# Altium schematic sheet mainboard_valdis.SchDoc
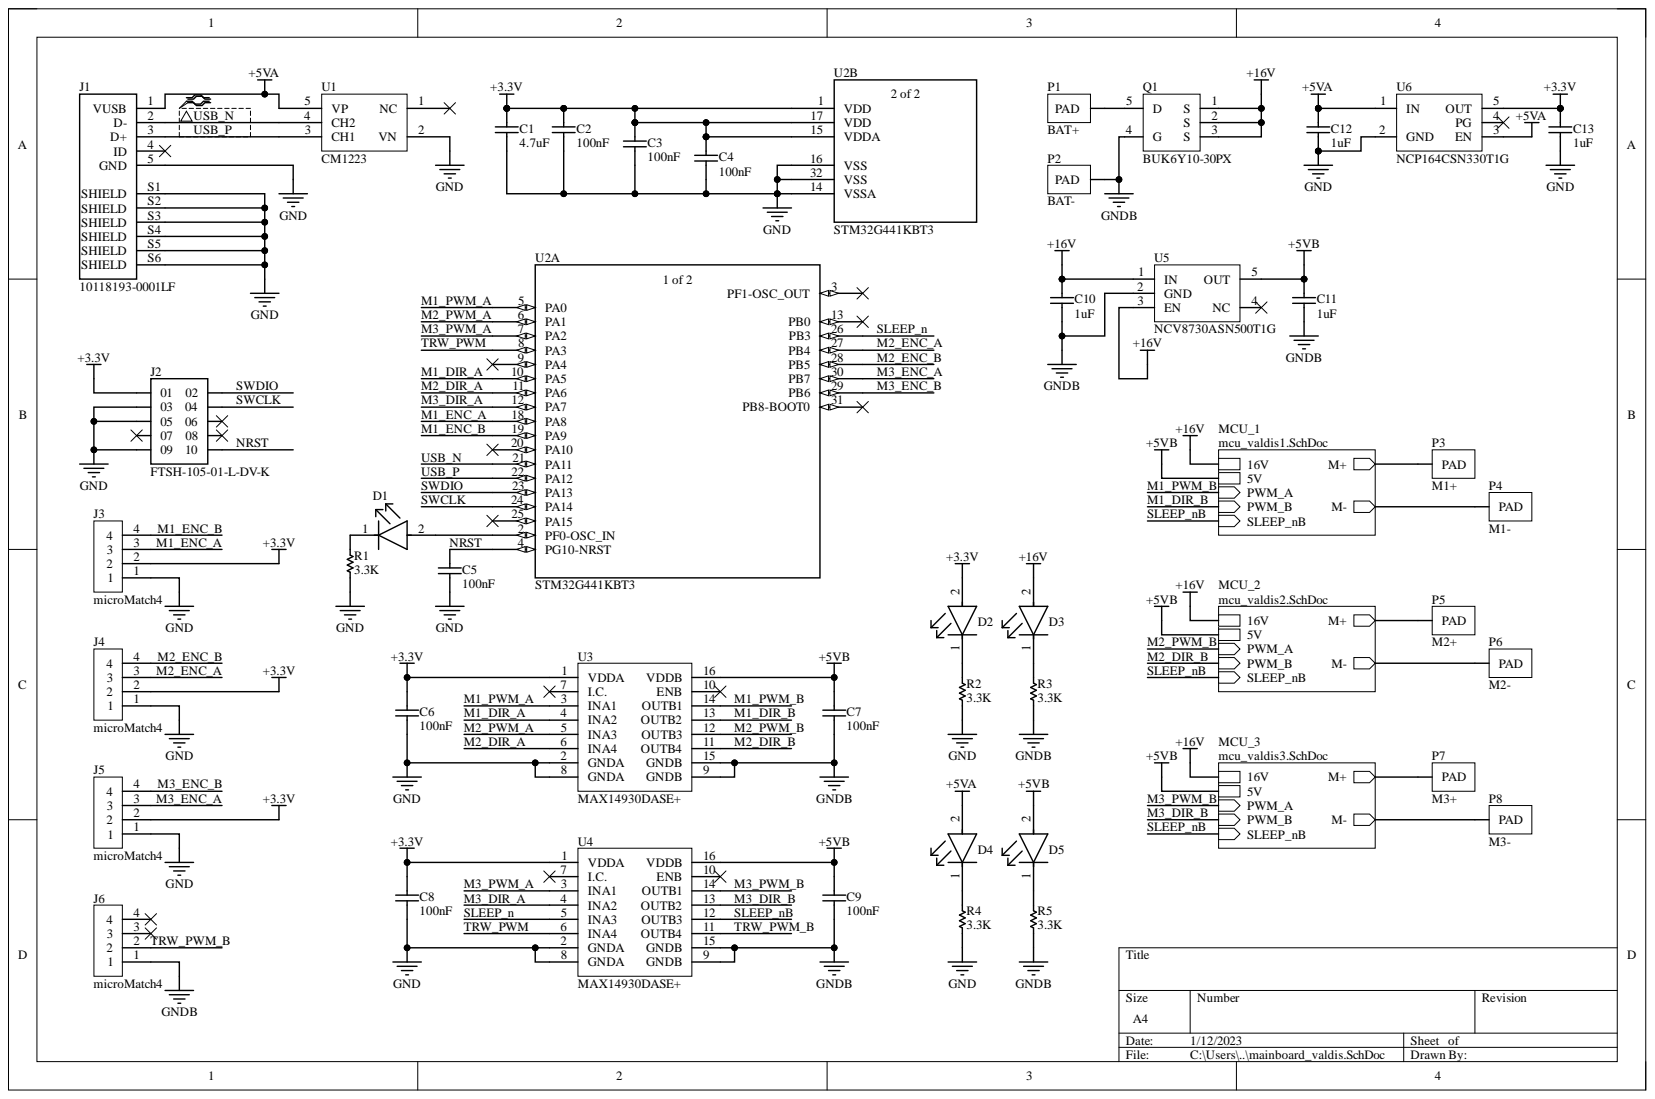
[diagram p0: mainboard_valdis.SchDoc]

## Parts
C1  4.7uF  [CAPC1608X90X35ML10T15]
C2  100nF  [CAPC1608X90X35ML10T15]
C3  100nF  [CAPC1608X90X35ML10T15]
C4  100nF  [CAPC1608X90X35ML10T15]
C5  100nF  [CAPC1608X90X35ML10T15]
C6  100nF  [CAPC1608X90X35ML10T15]
C7  100nF  [CAPC1608X90X35ML10T15]
C8  100nF  [CAPC1608X90X35ML10T15]
C9  100nF  [CAPC1608X90X35ML10T15]
C10  1uF  [CAPC1608X90X35ML10T15]
C11  1uF  [CAPC1608X90X35ML10T15]
C12  1uF  [CAPC1608X90X35ML10T15]
C13  1uF  [CAPC1608X90X35ML10T15]
D1  LTST-C191TBKT  [FP-LTST-C191TBKT-MFG]  (value not drawn)
D2  LTST-C191TBKT  [FP-LTST-C191TBKT-MFG]  (value not drawn)
D3  LTST-C191TBKT  [FP-LTST-C191TBKT-MFG]  (value not drawn)
D4  LTST-C191TBKT  [FP-LTST-C191TBKT-MFG]  (value not drawn)
D5  LTST-C191TBKT  [FP-LTST-C191TBKT-MFG]  (value not drawn)
J1  10118193-0001LF  [FCI_10118193-0001LF]
J2  FTSH-105-01-L-DV-K  [FTSH-105-01-XXX-DV-K]
J3  microMatch4  [microMatch4]
J4  microMatch4  [microMatch4]
J5  microMatch4  [microMatch4]
J6  microMatch4  [microMatch4]
P1  BAT+  [PCBComponent_1]
P2  BAT-  [PCBComponent_1]
P3  M1+  [PCBComponent_1]
P4  M1-  [PCBComponent_1]
P5  M2+  [PCBComponent_1]
P6  M2-  [PCBComponent_1]
P7  M3+  [PCBComponent_1]
P8  M3-  [PCBComponent_1]
Q1  BUK6Y10-30PX  [TO127P600X120-5N]
R1  3.3K  [RESC1609X50X30NL8T20]
R2  3.3K  [RESC1609X50X30NL8T20]
R3  3.3K  [RESC1609X50X30NL8T20]
R4  3.3K  [RESC1609X50X30NL8T20]
R5  3.3K  [RESC1609X50X30NL8T20]
U1  CM1223  [SOT23-5]
U2  STM32G441KBT3  [LQFP32_7X7_STM]  (parts A,B)
U3  MAX14930DASE+  [21-0041_S16+1_MXM]
U4  MAX14930DASE+  [21-0041_S16+1_MXM]
U5  NCV8730ASN500T1G  [TSSOP5]
U6  NCP164CSN330T1G  [SOP95P275X110-5N]

## Nets
+3.3V: C1.2 C2.2 C3.2 C4.2 C6.2 C8.2 C13.2 D2.2 J2.1 J3.2 J4.2 J5.2 U2.1(VDD) U2.15(VDDA) U2.17(VDD) U3.1(VDDA) U4.1(VDDA) U6.5(OUT)
+5VA: C12.2 D4.2 J1.1(VUSB) U1.5(VP) U6.1(IN) U6.3(EN)
+5VB: C7.2 C9.2 C11.2 D5.2 U3.16(VDDB) U4.16(VDDB) U5.5(OUT)
+16V: C10.2 D3.2 Q1.1(S) Q1.2(S) Q1.3(S) U5.1(IN) U5.3(EN)
GND: C1.1 C2.1 C3.1 C4.1 C5.1 C6.1 C8.1 C12.1 C13.1 J1.5(GND) J1.S1(SHIELD) J1.S2(SHIELD) J1.S3(SHIELD) J1.S4(SHIELD) J1.S5(SHIELD) J1.S6(SHIELD) J2.3 J2.5 J2.9 J3.1 J4.1 J5.1 R1.1 R2.1 R4.1 U1.2(VN) U2.14(VSSA) U2.16(VSS) U2.32(VSS) U3.2(GNDA) U3.8(GNDA) U4.2(GNDA) U4.8(GNDA) U6.2(GND)
GNDB: C7.1 C9.1 C10.1 C11.1 J6.1 P2.1 Q1.4(G) R3.1 R5.1 U3.9(GNDB) U3.15(GNDB) U4.9(GNDB) U4.15(GNDB) U5.2(GND)
M1_DIR_A: U2.10(PA5) U3.4(INA2)
M1_DIR_B: U3.13(OUTB2)
M1_ENC_A: J3.3 U2.18(PA8)
M1_ENC_B: J3.4 U2.19(PA9)
M1_PWM_A: U2.5(PA0) U3.3(INA1)
M1_PWM_B: U3.14(OUTB1)
M2_DIR_A: U2.11(PA6) U3.6(INA4)
M2_DIR_B: U3.11(OUTB4)
M2_ENC_A: J4.3 U2.27(PB4)
M2_ENC_B: J4.4 U2.28(PB5)
M2_PWM_A: U2.6(PA1) U3.5(INA3)
M2_PWM_B: U3.12(OUTB3)
M3_DIR_A: U2.12(PA7) U4.4(INA2)
M3_DIR_B: U4.13(OUTB2)
M3_ENC_A: J5.3 U2.30(PB7)
M3_ENC_B: J5.4 U2.29(PB6)
M3_PWM_A: U2.7(PA2) U4.3(INA1)
M3_PWM_B: U4.14(OUTB1)
NRST: C5.2 J2.10 U2.4(PG10-NRST)
SLEEP_n: U2.26(PB3) U4.5(INA3)
SLEEP_nB: U4.12(OUTB3)
SWCLK: J2.4 U2.24(PA14)
SWDIO: J2.2 U2.23(PA13)
TRW_PWM: U2.8(PA3) U4.6(INA4)
TRW_PWM_B: J6.2 U4.11(OUTB4)
USB_N: J1.2(D-) U1.4(CH2) U2.21(PA11)
USB_P: J1.3(D+) U1.3(CH1) U2.22(PA12)
NetD1_1: D1.1 R1.2
NetD1_2: D1.2 U2.2(PF0-OSC_IN)
NetD2_1: D2.1 R2.2
NetD3_1: D3.1 R3.2
NetD4_1: D4.1 R4.2
NetD5_1: D5.1 R5.2
NetJ1_4: J1.4(ID)
NetJ2_6: J2.6
NetJ2_7: J2.7
NetJ2_8: J2.8
NetJ6_3: J6.3
NetJ6_4: J6.4
NetP1_1: P1.1 Q1.5(D)
NetP3_1: P3.1
NetP4_1: P4.1
NetP5_1: P5.1
NetP6_1: P6.1
NetP7_1: P7.1
NetP8_1: P8.1
NetU1_1: U1.1(NC)
NetU2_3: U2.3(PF1-OSC_OUT)
NetU2_9: U2.9(PA4)
NetU2_13: U2.13(PB0)
NetU2_20: U2.20(PA10)
NetU2_25: U2.25(PA15)
NetU2_31: U2.31(PB8-BOOT0)
NetU3_7: U3.7(I.C.)
NetU3_10: U3.10(ENB)
NetU4_7: U4.7(I.C.)
NetU4_10: U4.10(ENB)
NetU5_4: U5.4(NC)
NetU6_4: U6.4(PG)

## Library data of the parts
C1-C13 = CMP-2000-04945-1: Chip Capacitor, 22 pF, +/- 5%, 50 V, -55 to 125 degC, 0603 (1608 Metric), RoHS, Tape and Reel; Manufacturer: Murata; Capacitance: 22pF; Dielectric: C0G; Rated Voltage: 50V; Case Size/Dimension: 0603; Component Kind: Standard; Datasheet URL: https://datasheet.ciiva.com/11786/0900766b807a1cab-11786398.pdf; Datasheet Version: Jul-06; Library Name: Altium Content Vault; Manufacturer URL: http://www.murata.com/; Package Description: 2-Pin Surface Mount Device, Body 1.6 x 0.8 mm; Package Reference: 603; Tolerance (%): ±5%
D1-D5 = CMP-22017-000008-1: LED BLUE CLEAR CHIP SMD; Dominant Wavelength: 475nm; Forward Current: 20mA; Forward Voltage: 3.8V; Lens Color: Colorless; Lens Style: Diffused; Luminous Intensity: 180mcd; Max Power Dissipation: 75mW; Number of Elements: 1; Power Dissipation: 76mW; Reverse Voltage: 5V; Viewing Angle: 130°; Wavelength: 468nm; Case Code (Imperial): 0603; Case Code (Metric): 1608; Case/Package: 0603; Color: Blue; Component Kind: Standard; Depth: 1.6mm; Height: 0.55mm; Illumination Color: Blue; Lead Free: Lead Free; Length: 0.8mm; Lens Transparency: Clear; Library Name: Altium Content Vault; Max Operating Temperature: 80°C; Min Operating Temperature: -20°C; Mount: Surface Mount; Number of LEDs: 1; Number of Pins: 2; Package Quantity: 1; Packaging: Tape and Reel; Radiation Hardening: No; Test Current: 20mA; Width: 0.8mm
J1 = 10118193-0001LF: USB - micro B USB 2.0 Receptacle Connector 5 Position Surface Mount, Right Angle; Through Hole; MF: Amphenol ICC; Availability: Unavailable; Component Kind: Standard; MP: 10118193-0001LF; Package: None; Price: None; SnapEDA_Link: https://www.snapeda.com/parts/10118193-0001LF/Amphenol%20FCI/view-part/2758989/?ref=snap; Library Name: Valdis_Components.IntLib
P1-P8 = Pad4x4: Component Kind: Standard; Library Name: Valdis_Components.IntLib
R1-R5 = CMP-2000-03816-5: Chip Resistor, 0 Ohm, Jumper, 100 mW, -55 to 155 degC, 0603 (1608 Metric), RoHS, Tape and Reel; Manufacturer: Vishay Semiconductor; Voltage Rating: 75 V; Component Kind: Standard; ComponentLink1Description: Manufacturer URL; ComponentLink1URL: http://www.vishay.com/; ComponentLink2Description: Datasheet; ComponentLink2URL: http://www.vishay.com/docs/20035/dcrcwe3.pdf; DatasheetVersion: 06/2012; Height: 0.45 mm; Length: 1.55 mm; Library Name: Altium Content Vault; Max Operating Temperature: 155 degC; Min Operating Temperature: -55 degC; Mount: Surface Mount; Mounting Technology: Surface Mount; Package Quantity: 5000; PackageDescription: 2-Pin Surface Mount Device, Body 1.575 x 0.85 mm; PackageReference: 0603; Packaging: Tape and Reel; Pins: 2; REACH SVHC: Unknown; RoHSCompliant: true
U2 = STM32G441KBT3: No Description Available; Built_By: EMA_Cory; SourceLibrary: Add_to_database_2020-11-05; Vendor: STMicroelectronics; Component Kind: Standard; Datasheet: https://www.st.com/resource/en/datasheet/stm32g441kb.pdf; Manufacturer_Part_Number: STM32G441KBT3; RefDes: RefDes; Type: DEV; Copyright: Copyright (C) 2018 Accelerated Designs. All rights reserved; Library Name: Valdis_Components.IntLib
J2 = FTSH-105-01-L-DV-K: Component Kind: Standard; Library Name: Valdis_Components.IntLib
J3-J6 = microMatch4: Component Kind: Standard; Library Name: Valdis_Components.IntLib
Q1 = BUK6Y10-30PX: Component Kind: Standard; Library Name: Valdis_Components.IntLib
U1 = CM1223: Component Kind: Standard; Library Name: Valdis_Components.IntLib
U3 U4 = MAX14930DASE+: Component Kind: Standard; Library Name: Valdis_Components.IntLib
U5 = NCV8730ASN500T1G: Component Kind: Standard; Library Name: NCV8730ASN500T1G.SchLib
U6 = NCP164CSN330T1G: Component Kind: Standard; Library Name: Valdis_Components.IntLib
